# Altium schematic sheet SUPPLY VOLTAGE.SchDoc("SUPPLY VOLTAGE")
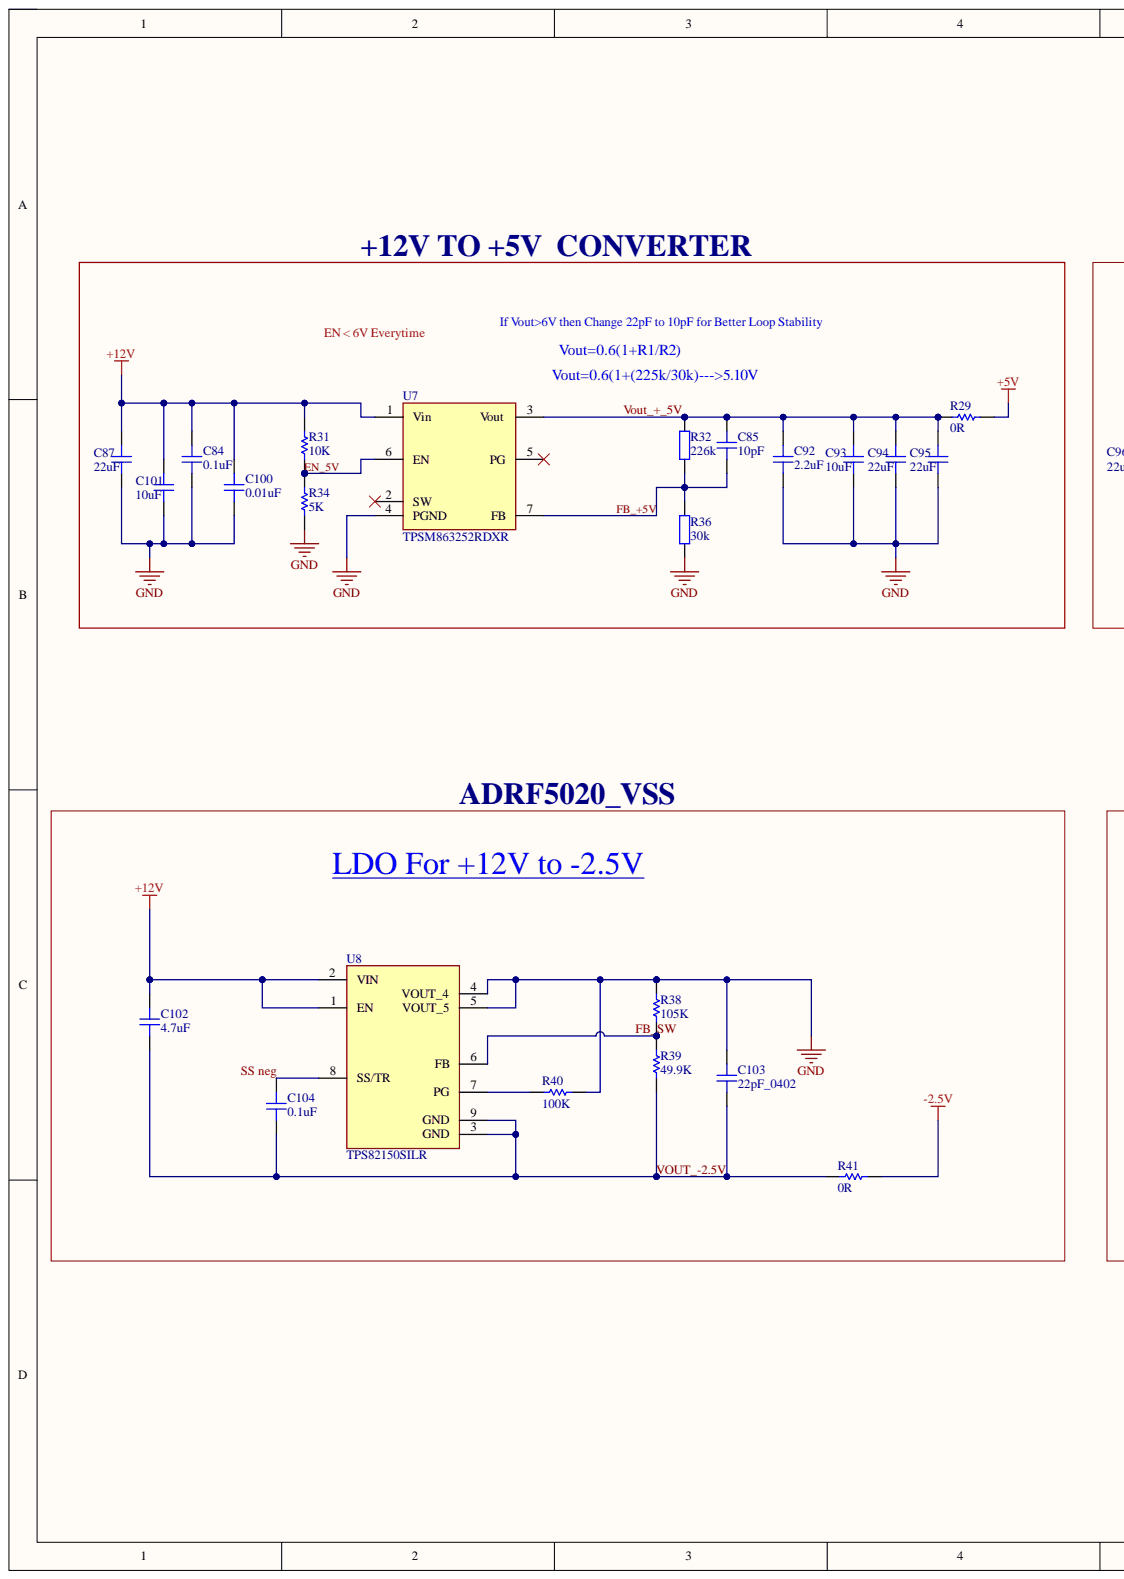
[diagram p0: SUPPLY VOLTAGE.SchDoc, left]
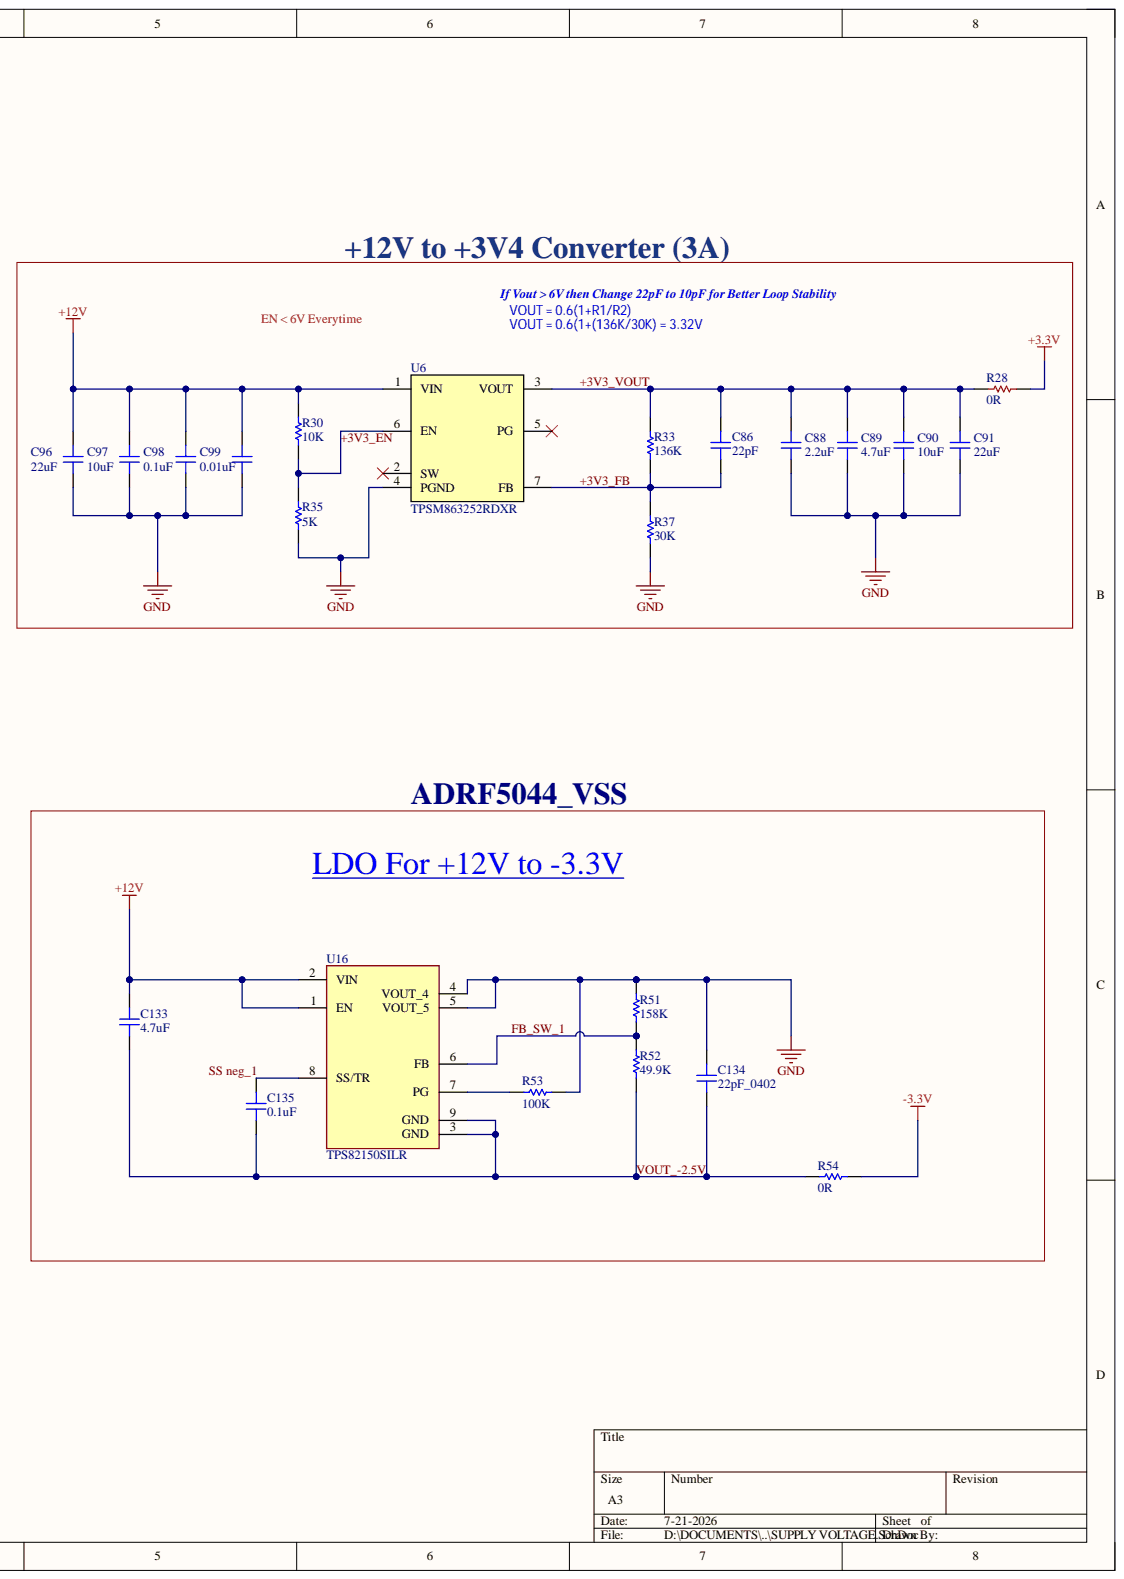
[diagram p1: SUPPLY VOLTAGE.SchDoc, right]

## Parts
C84  0.1uF  [CAP_0603]
C85  10pF  [CAP_0402]
C86  22pF  [CAP_0402]
C87  22uF  [CAP_1206]
C88  2.2uF  [CAP_0805]
C89  4.7uF  [CAP_1206]
C90  10uF  [CAP_1206]
C91  22uF  [CAP_1206]
C92  2.2uF  [CAP_0805]
C93  10uF  [CAP_1206]
C94  22uF  [CAP_1206]
C95  22uF  [CAP_1206]
C96  22uF  [CAP_1206]
C97  10uF  [CAP_1206]
C98  0.1uF  [CAP_0603]
C99  0.01uF  [CAP_0603]
C100  0.01uF  [CAP_0603]
C101  10uF  [CAP_1206]
C102  4.7uF  [CAP_0805]
C103  22pF_0402  [CAP_0402]
C104  0.1uF  [CAP_0402]
C133  4.7uF  [CAP_0805]
C134  22pF_0402  [CAP_0402]
C135  0.1uF  [CAP_0402]
R28  0R  [RES_1206]
R29  0R  [RES_0805]
R30  10K  [RES_0402]
R31  10K  [RES_0402]
R32  226k  [RES_0402]
R33  136K  [RES_0402]
R34  5K  [RES_0402]
R35  5K  [RES_0402]
R36  30k  [RES_0402]
R37  30K  [RES_0402]
R38  105K  [RES_0402]
R39  49.9K  [RES_0402]
R40  100K  [RES_0402]
R41  0R  [RES_0805]
R51  158K  [RES_0402]
R52  49.9K  [RES_0402]
R53  100K  [RES_0402]
R54  0R  [RES_0805]
U6  TPSM863252RDXR  [TPSM863252RDXR]
U7  TPSM863252RDXR  [RDX0007A-MFG]
U8  TPS82150SILR  [CONV_TPS82140SILR]
U16  TPS82150SILR  [CONV_TPS82140SILR]

## Nets
+3.3V: R28.2
+5V: R29.2
+12V: C84.2 C87.2 C96.2 C97.2 C98.2 C99.2 C100.2 C101.2 C102.2 C133.2 R30.2 R31.2 U6.1(VIN) U7.1(Vin) U8.1(EN) U8.2(VIN) U16.1(EN) U16.2(VIN)
-2.5V: R41.2
-3.3V: R54.2
GND: C84.1 C87.1 C88.1 C89.1 C90.1 C91.1 C92.1 C93.1 C94.1 C95.1 C96.1 C97.1 C98.1 C99.1 C100.1 C101.1 C103.2 C134.2 R34.1 R35.1 R36.1 R37.1 R38.2 R40.2 R51.2 R53.2 U6.4(PGND) U7.4(PGND) U8.4(VOUT_4) U8.5(VOUT_5) U16.4(VOUT_4) U16.5(VOUT_5)
+3V3_EN: R30.1 R35.2 U6.6(EN)
+3V3_FB: C86.2 R33.1 R37.2 U6.7(FB)
+3V3_VOUT: C86.1 C88.2 C89.2 C90.2 C91.2 R28.1 R33.2 U6.3(VOUT)
EN_5V: R31.1 R34.2 U7.6(EN)
FB_+5V: C85.2 R32.1 R36.2 U7.7(FB)
FB_SW: R38.1 R39.2 U8.6(FB)
FB_SW_1: R51.1 R52.2 U16.6(FB)
SS neg: C104.2 U8.8(SS/TR)
SS neg_1: C135.2 U16.8(SS/TR)
Vout_+_5V: C85.1 C92.2 C93.2 C94.2 C95.2 R29.1 R32.2 U7.3(Vout)
VOUT_-2.5V: C102.1 C103.1 C104.1 C133.1 C134.1 C135.1 R39.1 R41.1 R52.1 R54.1 U8.3(GND) U8.9(GND) U16.3(GND) U16.9(GND)
NetR40_1: R40.1 U8.7(PG)
NetR53_1: R53.1 U16.7(PG)

Not connected: U6 2(SW) 5(PG); U7 2(SW) 5(PG)

## Library data of the parts
C84 C98-C100 = CAP_0603_25V: Capacitor SMD 0603 25V; Component Kind: Standard; Library Name: Schematic-Lib-PhilsLab.SchLib
C85 C86 = CAP_0402_50V: Capacitor SMD 0402 50V; Component Kind: Standard; Library Name: Schematic-Lib-PhilsLab.SchLib
C87 C96 = CAP_1206_25V: C1206_50V-GRM21BR61A226ME44L; Component Kind: Standard; Library Name: FPGA_LIB.SchLib
C88 C92 = CAP_0805_16V: Capacitor SMD 0805 16V; Component Kind: Standard; Library Name: Schematic-Lib-PhilsLab.SchLib
C89-C91 C93-C95 C97 C101 = CAP_1206_25V: C1206_50V; Component Kind: Standard; Library Name: FPGA_LIB.SchLib
C102 C133 = CAP_0805_50V: C0805_50V; Component Kind: Standard; Library Name: MAX10.SchLib
C103 C134 = CAP_0402_25V: C0402_25V; Component Kind: Standard; Library Name: MAX10.SchLib
C104 C135 = CAP_0402_16V: C0402_16V; Component Kind: Standard; Library Name: ANAND_LIB.SchLib
R28 = RES_1206: R1206; Component Kind: Standard; Library Name: FPGA_LIB.SchLib
R29 R41 R54 = RES_0805: R0805; Component Kind: Standard
  R29: Library Name: FPGA_LIB.SchLib
  R41 R54: Library Name: ALTIUM_LIB.SchLib
R30 R31 R33-R35 R37 R40 R53 = RES_0402: R0402; Component Kind: Standard
  R30 R35: Library Name: ALTIUM_LIB.SchLib
  R33 R37: Library Name: FPGA_LIB.SchLib
  R31 R34: Library Name: ANAND_LIB.SchLib
  R40 R53: Library Name: Chaitra_Altium_LIB.SchLib
R32 R36 = RES_0402: Resistor SMD 0402; Component Kind: Standard; Library Name: Schematic-Lib-PhilsLab.SchLib
R38 R39 R51 R52 = RES_0402: Component Kind: Standard; Description: RES_0402; Library Name: ANAND_LIB.SchLib
U6 = TPSM863252RDXR: 3-17 Vin, 3A Eco-Mode Sync-Buck; Component Kind: Standard; Library Name: FPGA_LIB.SchLib; MPN: TPSM863252RDXR
U7 = TPSM863252: 3-V to 17-V input voltage, 3-A Eco-mode synchronous buck module; Component Kind: Standard; Library Name: FPGA_LIB.SchLib
U8 U16 = TPS82150SILR: Non-Isolated DC/DC Converters 17V Input 1A Synchro nous Step-Down Conve A 595-TPS82150SILT; Availability: Unavailable; Component Kind: Standard; MP: TPS82150SILR; Package: None; Price: None; Library Name: ANAND_LIB.SchLib
